# Altium schematic sheet Measure.SchDoc
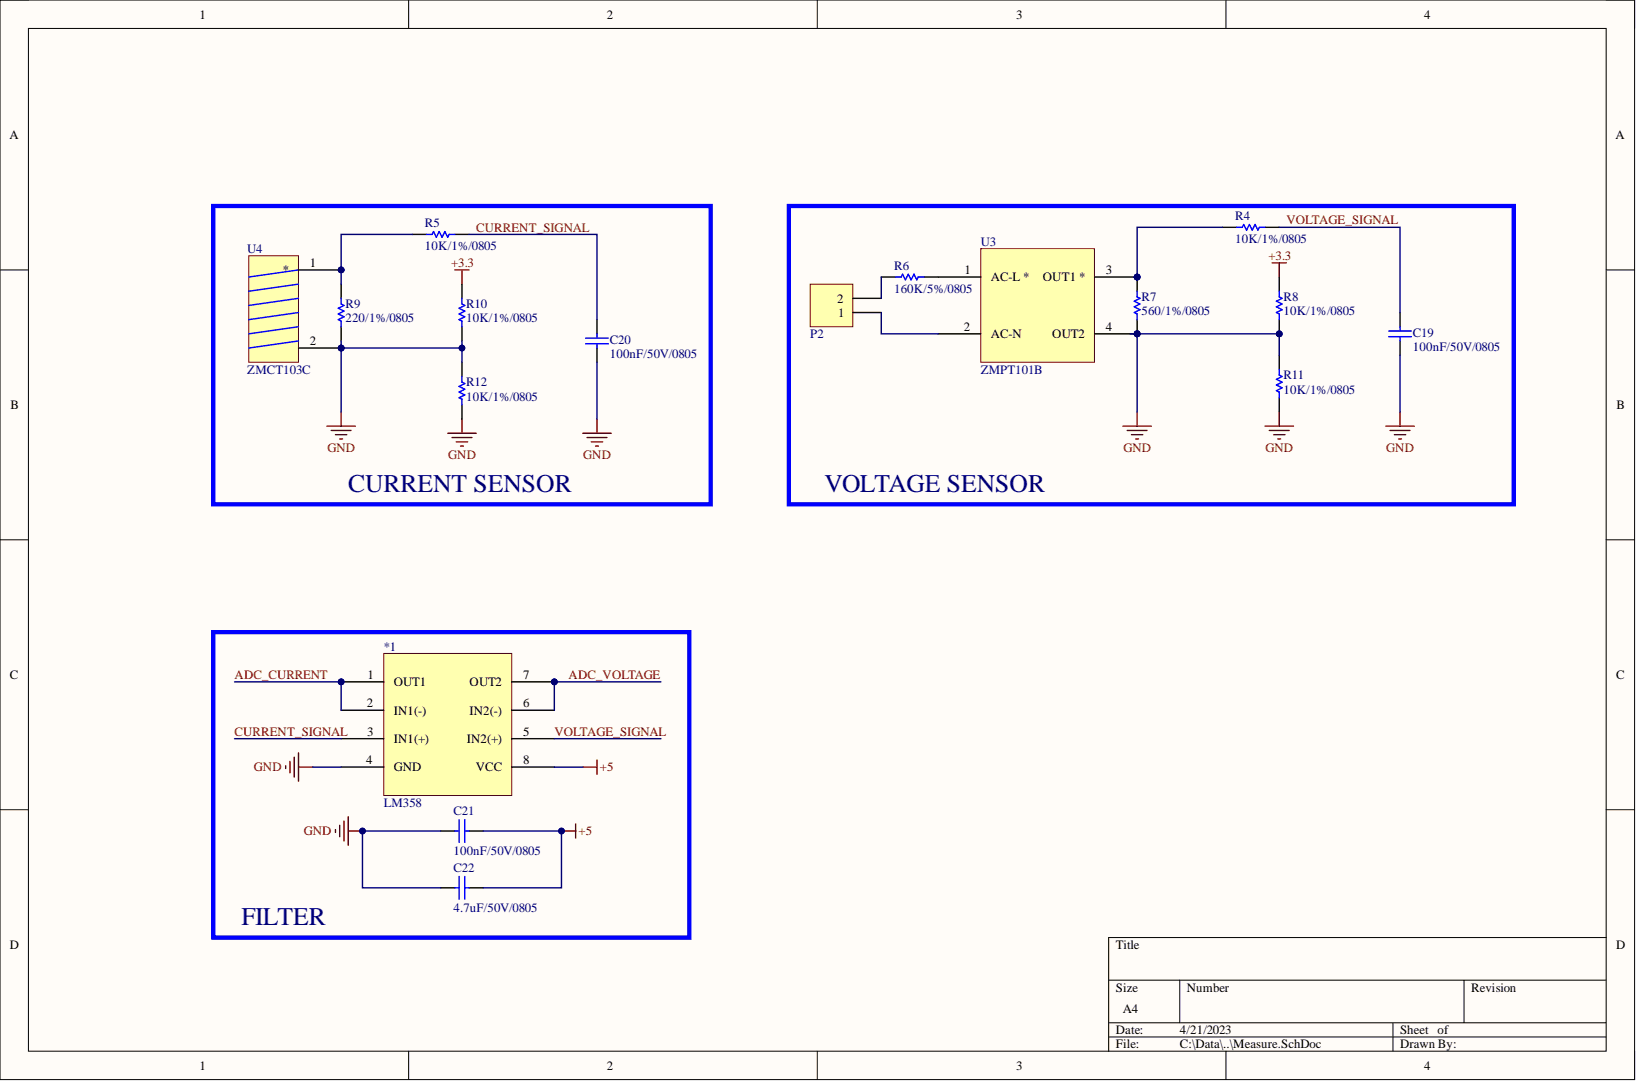
[diagram p0: Measure.SchDoc]

## Parts
*1  LM358  [DIP8]
C19  value 100nF/50V/0805  [CAP-SM-0805]  (hidden comment: Cap Non-Pol SMD)
C20  value 100nF/50V/0805  [CAP-SM-0805]  (hidden comment: Cap Non-Pol SMD)
C21  value 100nF/50V/0805  [CAP-SM-0805]  (hidden comment: Cap Non-Pol SMD)
C22  value 4.7uF/50V/0805  [CAP-SM-0805]  (hidden comment: Cap Non-Pol SMD)
P2  Domino 2  [DOMINO 2- 5mm]  (value not drawn)
R4  value 10K/1%/0805  [RES-SM-0805]  (hidden comment: RESISTOR SMD)
R5  value 10K/1%/0805  [RES-SM-0805]  (hidden comment: RESISTOR SMD)
R6  value 160K/5%/0805  [RES-SM-0805]  (hidden comment: RESISTOR SMD)
R7  value 560/1%/0805  [RES-SM-0805]  (hidden comment: RESISTOR SMD)
R8  value 10K/1%/0805  [RES-SM-0805]  (hidden comment: RESISTOR SMD)
R9  value 220/1%/0805  [RES-SM-0805]  (hidden comment: RESISTOR SMD)
R10  value 10K/1%/0805  [RES-SM-0805]  (hidden comment: RESISTOR SMD)
R11  value 10K/1%/0805  [RES-SM-0805]  (hidden comment: RESISTOR SMD)
R12  value 10K/1%/0805  [RES-SM-0805]  (hidden comment: RESISTOR SMD)
U3  ZMPT101B  [ZMPT101B]
U4  ZMCT103C  [ZMCT103]

## Nets
+3.3: R8.2 R10.2
+5: *1.8(VCC) C21.1 C22.1
GND: *1.4(GND) C19.1 C20.1 C21.2 C22.2 R7.2 R8.1 R9.1 R10.1 R11.1 R11.2 R12.1 R12.2 U3.4(OUT2) U4.2
ADC_CURRENT: *1.1(OUT1) *1.2(IN1(-))
ADC_VOLTAGE: *1.6(IN2(-)) *1.7(OUT2)
CURRENT_SIGNAL: *1.3(IN1(+)) C20.2 R5.1
VOLTAGE_SIGNAL: *1.5(IN2(+)) C19.2 R4.1
NetP2_1: P2.1 U3.2(AC-N)
NetP2_2: P2.2 R6.2
NetR4_2: R4.2 R7.1 U3.3(OUT1_*)
NetR5_2: R5.2 R9.2 U4.1
NetR6_1: R6.1 U3.1(AC-L_*)

## Library data of the parts
C19-C22 = Cap Non-Pol SMD: Capacitor; Component Kind: Standard; Library Name: PIF_Library.IntLib
P2 = Domino 2: Domino, 2-Pin; Component Kind: Standard; LatestRevisionDate: 17-Jul-2002; LatestRevisionNote: Re-released for DXP Platform.; Library Name: PIF_Library.IntLib; Publisher: Altium Limited
R4-R12 = RESISTOR SMD: Resistor; Component Kind: Standard; Library Name: PIF_Library.IntLib
U3 = ZMPT101B: Minuscule 2mA/2mA Precision Voltage Transformer; Component Kind: Standard; Library Name: Giang's Libs.IntLib
U4 = ZMCT103C: Precision Current Sensor 5A; Component Kind: Standard; Library Name: Giang's Libs.IntLib
*1 = LM358: Component Kind: Standard; Library Name: MiclsLib.IntLib
